# Altium schematic sheet 03 MCU_Disp_Power.SchDoc("03 MCU_Disp_Power")
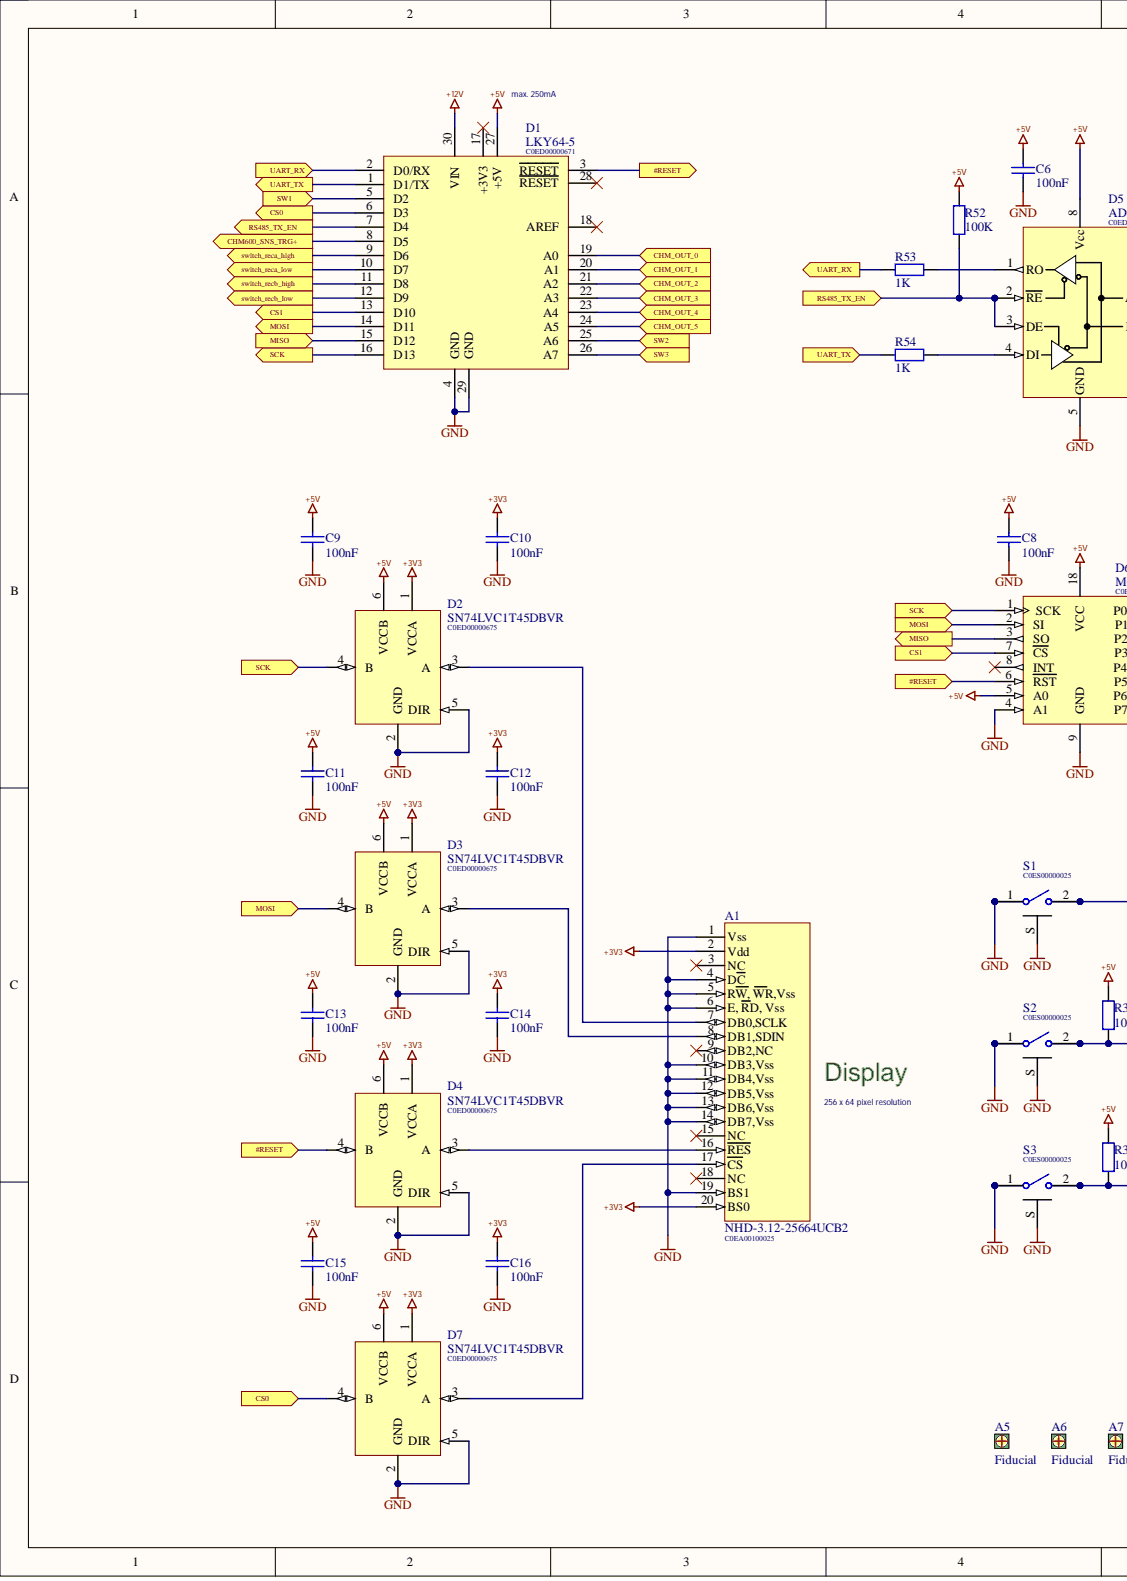
[diagram p0: 03 MCU_Disp_Power.SchDoc, left]
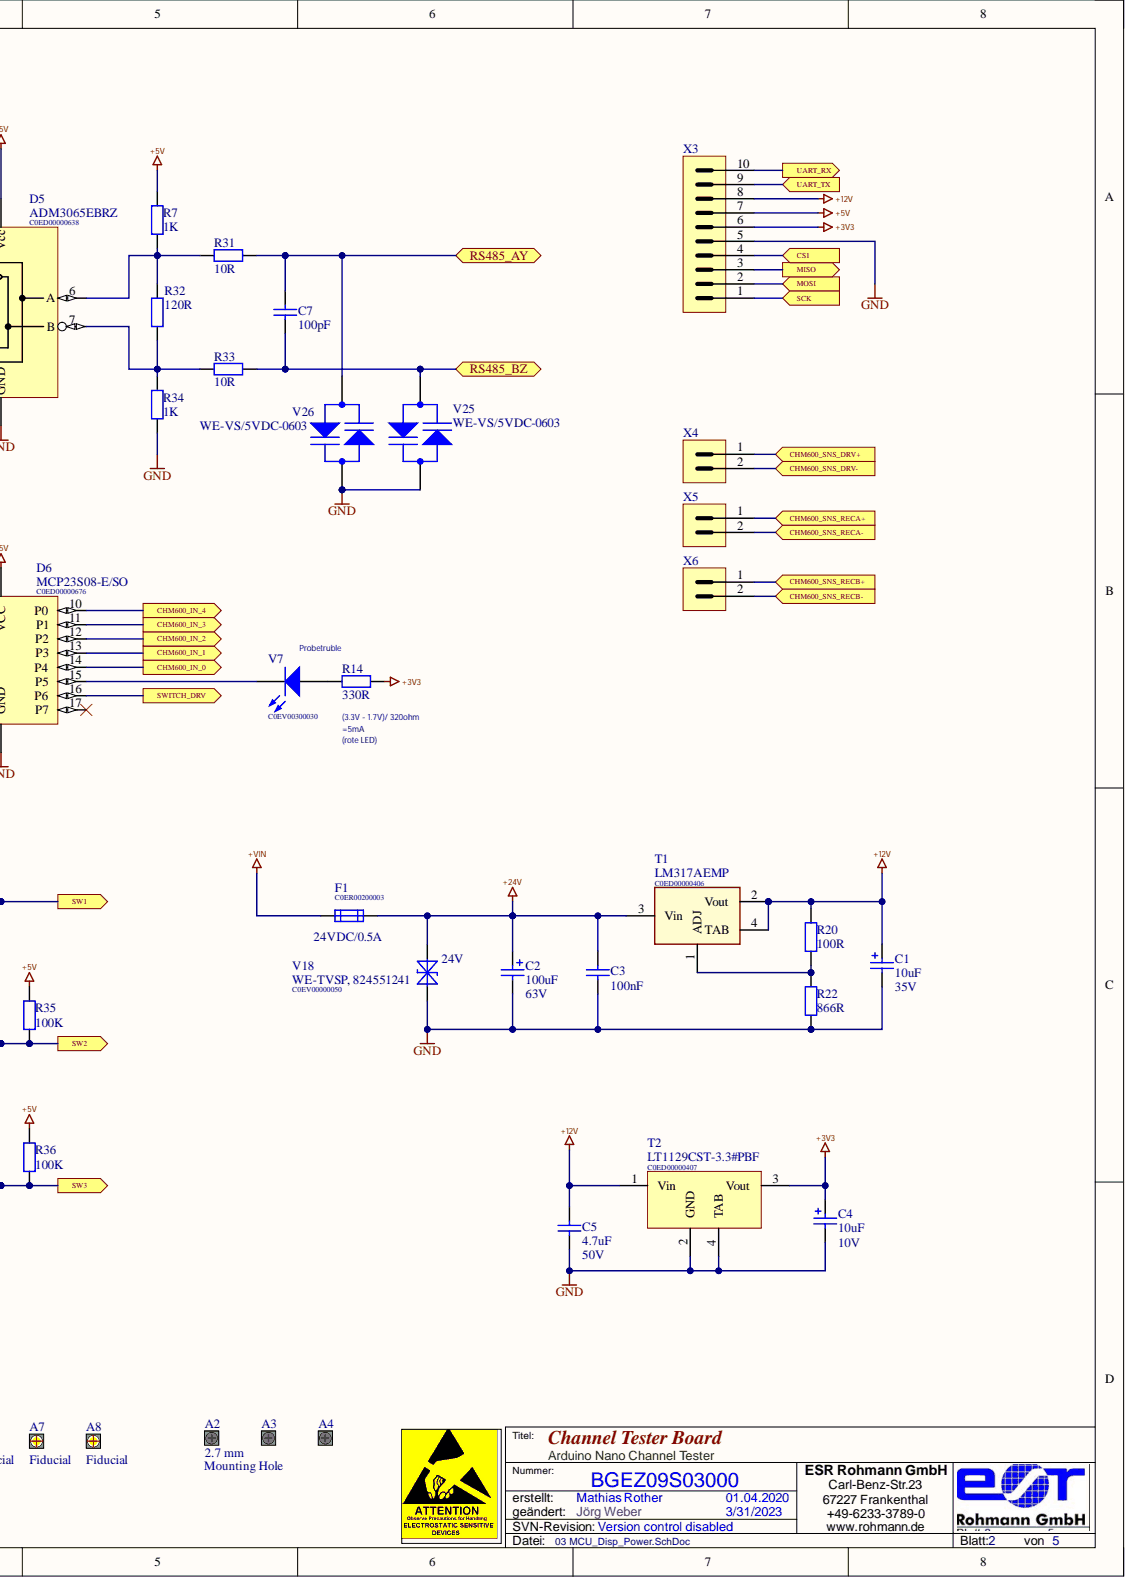
[diagram p1: 03 MCU_Disp_Power.SchDoc, right]

## Parts
A1  value NHD-3.12-25664UCB2  (hidden comment: DISPLAY_OLED)
A2  Mounting Hole  value 2.7 mm
A3  Mounting Hole
A4  Mounting Hole
A5  Fiducial
A6  Fiducial
A7  Fiducial
A8  Fiducial
C1  value 10uF  VOLTAGE: 35V  (hidden comment: CapPol)
C2  value 100uF  VOLTAGE: 63V  (hidden comment: Cap Pol1)
C3  value 100nF  (hidden comment: Cap)
C4  value 10uF  VOLTAGE: 10V  (hidden comment: CapPol)
C5  value 4.7uF  VOLTAGE: 50V  (hidden comment: Cap)
C6  value 100nF  (hidden comment: Cap)
C7  value 100pF  (hidden comment: Cap)
C8  value 100nF  (hidden comment: Cap)
C9  value 100nF  (hidden comment: Cap)
C10  value 100nF  (hidden comment: Cap)
C11  value 100nF  (hidden comment: Cap)
C12  value 100nF  (hidden comment: Cap)
C13  value 100nF  (hidden comment: Cap)
C14  value 100nF  (hidden comment: Cap)
C15  value 100nF  (hidden comment: Cap)
C16  value 100nF  (hidden comment: Cap)
D1  value LKY64-5  SEARCH1: LKY64-5  (hidden comment: Arduino_Nano_V3)
D2  value SN74LVC1T45DBVR  SEARCH1: SN74LVC1T45DBVR  (hidden comment: Single-Bit Dual-Supply Bus Transceiver)
D3  value SN74LVC1T45DBVR  SEARCH1: SN74LVC1T45DBVR  (hidden comment: Single-Bit Dual-Supply Bus Transceiver)
D4  value SN74LVC1T45DBVR  SEARCH1: SN74LVC1T45DBVR  (hidden comment: Single-Bit Dual-Supply Bus Transceiver)
D5  ADM3065  value ADM3065EBRZ  SEARCH1: ADM3065EBRZ
D6  MCP23S08  value MCP23S08-E/SO  SEARCH1: MCP23S08-E/SO
D7  value SN74LVC1T45DBVR  SEARCH1: SN74LVC1T45DBVR  (hidden comment: Single-Bit Dual-Supply Bus Transceiver)
F1  RATING: 24VDC/0.5A  (hidden comment: FUSE)
R7  value 1K  (hidden comment: Res2)
R14  value 330R  (hidden comment: Res2)
R20  value 100R  (hidden comment: Res2)
R22  value 866R  (hidden comment: Res2)
R31  value 10R  (hidden comment: Res2)
R32  value 120R  (hidden comment: Res2)
R33  value 10R  (hidden comment: Res2)
R34  value 1K  (hidden comment: Res2)
R35  value 100K  (hidden comment: Res2)
R36  value 100K  (hidden comment: Res2)
R52  value 100K  (hidden comment: Res2)
R53  value 1K  (hidden comment: Res2)
R54  value 1K  (hidden comment: Res2)
S1  SW_WS-TASV  (value not drawn)
S2  SW_WS-TASV  (value not drawn)
S3  SW_WS-TASV  (value not drawn)
T1  value LM317AEMP  SEARCH1: LM317AEMP  (hidden comment: LM317_SOT23)
T2  LT1129CST  value LT1129CST-3.3#PBF  SEARCH1: LT1129CST-3.3#PBF
V7  LED
V18  value WE-TVSP, 824551241  VOLTAGE: 24V  (hidden comment: TVS-DIODE-UNI)
V25  value WE-VS/5VDC-0603  (hidden comment: VARISTOR_BI-DIR)
V26  value WE-VS/5VDC-0603  (hidden comment: VARISTOR_BI-DIR)
X3  HEADER_1X10  (value not drawn)
X4  HEADER_1X2  (value not drawn)
X5  HEADER_1X2  (value not drawn)
X6  HEADER_1X2  (value not drawn)

## Ports
#RESET, CHM600_IN_0, CHM600_IN_1, CHM600_IN_2, CHM600_IN_3, CHM600_IN_4, CHM600_SNS_DRV+, CHM600_SNS_DRV-, CHM600_SNS_RECA+, CHM600_SNS_RECA-, CHM600_SNS_RECB+, CHM600_SNS_RECB-, CHM600_SNS_TRG+, CHM_OUT_0, CHM_OUT_1, CHM_OUT_2, CHM_OUT_3, CHM_OUT_4, CHM_OUT_5, CS0, CS1, MISO, MOSI, RS485_AY, RS485_BZ, RS485_TX_EN, SCK, SW1, SW2, SW3, SWITCH_DRV, switch_reca_high, switch_reca_low, switch_recb_high, switch_recb_low, UART_RX, UART_TX

## Nets
+3V3: A1.2(Vdd) A1.20(BS0) C4.P C10.2 C12.2 C14.2 C16.2 D2.1(VCCA) D3.1(VCCA) D4.1(VCCA) D7.1(VCCA) R14.2 T2.3(Vout) X3.6
+5V: C6.2 C8.2 C9.2 C11.2 C13.2 C15.2 D1.27(+5V) D2.6(VCCB) D3.6(VCCB) D4.6(VCCB) D5.8(Vcc) D6.5(A0) D6.18(VCC) D7.6(VCCB) R7.2 R35.2 R36.2 R52.2 X3.7
+12V: C1.P C5.2 D1.30(VIN) R20.2 T1.2(Vout) T1.4(TAB) T2.1(Vin) X3.8
+24V: C2.1 C3.2 F1.2 T1.3(Vin) V18.1
+VIN: F1.1
GND: A1.1(Vss) A1.4(DC) A1.5(RW,_WR,Vss) A1.6(E,_RD,_Vss) A1.10(DB3,Vss) A1.11(DB4,Vss) A1.12(DB5,Vss) A1.13(DB6,Vss) A1.14(DB7,Vss) A1.19(BS1) C1.N C2.2 C3.1 C4.N C5.1 C6.1 C8.1 C9.1 C10.1 C11.1 C12.1 C13.1 C14.1 C15.1 C16.1 D1.4(GND) D1.29(GND) D2.2(GND) D2.5(DIR) D3.2(GND) D3.5(DIR) D4.2(GND) D4.5(DIR) D5.5(GND) D6.4(A1) D6.9(GND) D7.2(GND) D7.5(DIR) R22.1 R34.1 S1.1 S1.3 S1.S S2.1 S2.3 S2.S S3.1 S3.3 S3.S T2.2(GND) T2.4(TAB) V18.2 V25.1 V26.1 X3.5
#RESET: D1.3(RESET) D4.4(B) D6.6(RST) ; port #RESET
CHM600_IN_0: D6.14(P4) ; port CHM600_IN_0
CHM600_IN_1: D6.13(P3) ; port CHM600_IN_1
CHM600_IN_2: D6.12(P2) ; port CHM600_IN_2
CHM600_IN_3: D6.11(P1) ; port CHM600_IN_3
CHM600_IN_4: D6.10(P0) ; port CHM600_IN_4
CHM600_SNS_DRV+: X4.1 ; port CHM600_SNS_DRV+
CHM600_SNS_DRV-: X4.2 ; port CHM600_SNS_DRV-
CHM600_SNS_RECA+: X5.1 ; port CHM600_SNS_RECA+
CHM600_SNS_RECA-: X5.2 ; port CHM600_SNS_RECA-
CHM600_SNS_RECB+: X6.1 ; port CHM600_SNS_RECB+
CHM600_SNS_RECB-: X6.2 ; port CHM600_SNS_RECB-
CHM600_SNS_TRG+: D1.8(D5) ; port CHM600_SNS_TRG+
CHM_OUT_0: D1.19(A0) ; port CHM_OUT_0
CHM_OUT_1: D1.20(A1) ; port CHM_OUT_1
CHM_OUT_2: D1.21(A2) ; port CHM_OUT_2
CHM_OUT_3: D1.22(A3) ; port CHM_OUT_3
CHM_OUT_4: D1.23(A4) ; port CHM_OUT_4
CHM_OUT_5: D1.24(A5) ; port CHM_OUT_5
CS0: D1.6(D3) D7.4(B) ; port CS0
CS1: D1.13(D10) D6.7(CS) X3.4 ; port CS1
MISO: D1.15(D12) D6.3(SO) X3.3 ; port MISO
MOSI: D1.14(D11) D3.4(B) D6.2(SI) X3.2 ; port MOSI
RS485_AY: C7.2 R31.2 V26.2 ; port RS485_AY
RS485_BZ: C7.1 R33.2 V25.2 ; port RS485_BZ
RS485_TX_EN: D1.7(D4) D5.2(RE) D5.3(DE) R52.1 ; port RS485_TX_EN
SCK: D1.16(D13) D2.4(B) D6.1(SCK) X3.1 ; port SCK
SW1: D1.5(D2) S1.2 S1.4 ; port SW1
SW2: D1.25(A6) R35.1 S2.2 S2.4 ; port SW2
SW3: D1.26(A7) R36.1 S3.2 S3.4 ; port SW3
SWITCH_DRV: D6.16(P6) ; port SWITCH_DRV
switch_reca_high: D1.9(D6) ; port switch_reca_high
switch_reca_low: D1.10(D7) ; port switch_reca_low
switch_recb_high: D1.11(D8) ; port switch_recb_high
switch_recb_low: D1.12(D9) ; port switch_recb_low
UART_RX: D1.2(D0/RX) R53.2 X3.10 ; port UART_RX
UART_TX: D1.1(D1/TX) R54.2 X3.9 ; port UART_TX
NetA1_7: A1.7(DB0,SCLK) D2.3(A)
NetA1_8: A1.8(DB1,SDIN) D3.3(A)
NetA1_16: A1.16(RES) D4.3(A)
NetA1_17: A1.17(CS) D7.3(A)
NetD5_1: D5.1(RO) R53.1
NetD5_4: D5.4(DI) R54.1
NetD5_6: D5.6(A) R7.1 R31.1 R32.2
NetD5_7: D5.7(B) R32.1 R33.1 R34.2
NetD6_15: D6.15(P5) V7.C
NetR14_1: R14.1 V7.A
NetR20_1: R20.1 R22.2 T1.1(ADJ)

Not connected: A1 3(NC) 9(DB2,NC) 15(NC) 18(NC); D1 17(+3V3) 18(AREF) 28(RESET); D6 8(INT) 17(P7)

## Library data of the parts
A1 = C0EA00100025: Newhaven Graphic OLED Display Module,  3.12” diagonal size, 256 x 64 pixel resolution, +2.95V power supply; PART_TYPE: OPTO; Database Table Name: PART_NUMBER_VIEW; REVIEW_STATE: OK; Component Kind: Standard; ComponentLink1Description: Datasheet; ComponentLink1URL: http://apsharepoint/DMS/APplusProd/Forms/Allitems.aspx?RootFolder=%2FDMS%2FAPplusProd%2Fartikel%2FC0EA00100025; ComponentLink2Description: AP-Plus; ComponentLink2URL: http://asseco/APplusProd/masterdata/artikelRec.aspx?ARTIKEL.ARTIKEL=C0EA00100025; ESD-sensitivity: ESD-sensitivity; PART_NUMBER: C0EA00100025; Library Name: esr.SVNDBlib
A2-A4 = Z0000018: Unplated Mounting Hole 2.7mm, Keepout 2.7 mm; PART_TYPE: ELEKTROMECHANISCH; Database Table Name: PART_NUMBER_VIEW; REVIEW_STATE: OK; Component Kind: Standard; ComponentLink1Description: Datasheet; ComponentLink1URL: http://apsharepoint/DMS/APplusProd/Forms/Allitems.aspx?RootFolder=%2FDMS%2FAPplusProd%2Fartikel%2FZ0000018; ComponentLink2Description: AP-Plus; ComponentLink2URL: http://asseco/APplusProd/masterdata/artikelRec.aspx?ARTIKEL.ARTIKEL=Z0000018; ESD-sensitivity: ESD-sensitivity; PART_NUMBER: Z0000018; Library Name: esr.SVNDBlib
  A3 A4: VALUE: 2.7 mm
A5-A8 = Z0000013: Fiducial round; PART_TYPE: ELEKTROMECHANISCH; ACTIVE: Do Not Use; Database Table Name: PART_NUMBER_VIEW; REVIEW_STATE: OK; Component Kind: Standard; ComponentLink1Description: Datasheet; ComponentLink1URL: http://apsharepoint/DMS/APplusProd/Forms/Allitems.aspx?RootFolder=%2FDMS%2FAPplusProd%2Fartikel%2FZ0000013; ComponentLink2Description: AP-Plus; ComponentLink2URL: http://asseco/APplusProd/masterdata/artikelRec.aspx?ARTIKEL.ARTIKEL=Z0000013; ESD-sensitivity: ESD-sensitivity; PART_NUMBER: Z0000013; Library Name: esr.SVNDBlib
C1 = C0EC00000003: Tantal-Elektrolyt-Kondensator TAJ 10uF/35V/10%; PART_TYPE: KONDENSATOREN; Database Table Name: PART_NUMBER_VIEW; PCB_FOOTPRINT: CAPMP7343X280N,CAPMP7343X280L,c_smdd; REVIEW_STATE: OK; SEARCH1: 10uF/35V-SMD-D; SEARCH2: C,SMD,10UF; Component Kind: Standard; ComponentLink1Description: Datasheet; ComponentLink1URL: http://apsharepoint/DMS/APplusProd/Forms/Allitems.aspx?RootFolder=%2FDMS%2FAPplusProd%2Fartikel%2FC0EC00000003; ComponentLink2Description: AP-Plus; ComponentLink2URL: http://asseco/APplusProd/masterdata/artikelRec.aspx?ARTIKEL.ARTIKEL=C0EC00000003; ESD-sensitivity: ESD-sensitivity; PART_NUMBER: C0EC00000003; PRICE: .24; ROHS: ROK; SCHEMATIC_PART: CAP POL; STORAGE: EA0408; Library Name: esr.SVNDBlib
C2 = C0EC00000023: SMD-Aluminium-Elektrolyt-Kondensator, 100uF/50V/20%, Serie ECA, Bauform G; MANUFACTURER: Vishay Measurements Group GmbH; PART_TYPE: KONDENSATOREN; SCHEMATIC_PART: CAP POL; Database Table Name: PART_NUMBER_VIEW; PCB_FOOTPRINT: CAPAE1030X1050N; REVIEW_STATE: OK; SEARCH1: MALSECA00AG310HARK; SEARCH2: C,SMD,100UF; Component Kind: Standard; ComponentLink1Description: Datasheet; ComponentLink1URL: http://apsharepoint/DMS/APplusProd/Forms/Allitems.aspx?RootFolder=%2FDMS%2FAPplusProd%2Fartikel%2FC0EC00000023; ComponentLink2Description: AP-Plus; ComponentLink2URL: http://asseco/APplusProd/masterdata/artikelRec.aspx?ARTIKEL.ARTIKEL=C0EC00000023; ESD-sensitivity: ESD-sensitivity; PART_NUMBER: C0EC00000023; ROHS: ROK; STORAGE: EA0408; Library Name: esr.SVNDBlib
C3 = C002100N: Chip-Kondensator 100nF/50V/5%/X7R; PART_TYPE: KONDENSATOREN; SCHEMATIC_PART: CAP NP; Database Table Name: PART_NUMBER_VIEW; PCB_FOOTPRINT: CAPC2012X145N,CAPC2012X145L,c_0805; REVIEW_STATE: OK; SEARCH1: 100N-SMD0805; SEARCH2: C,SMD,100N; Component Kind: Standard; ComponentLink1Description: Datasheet; ComponentLink1URL: http://apsharepoint/DMS/APplusProd/Forms/Allitems.aspx?RootFolder=%2FDMS%2FAPplusProd%2Fartikel%2FC002100N; ComponentLink2Description: AP-Plus; ComponentLink2URL: http://asseco/APplusProd/masterdata/artikelRec.aspx?ARTIKEL.ARTIKEL=C002100N; ESD-sensitivity: ESD-sensitivity; PART_NUMBER: C002100N; PRICE: .02; ROHS: ROK; STORAGE: EA0408; Library Name: esr.SVNDBlib
C4 = C0EC00000018: SMD-Tantal-Elektrolyt-Kondensator, 10uF/10V/10%, LowESR, Serie TPS, Bauform A; MANUFACTURER: AVX; PART_TYPE: KONDENSATOREN; Database Table Name: PART_NUMBER_VIEW; PCB_FOOTPRINT: CAPMP3216X180N,CAPMP3216X180L,c_smda; REVIEW_STATE: OK; SEARCH1: TPSA106K010R0900; SEARCH2: C,SMD,10UF; Component Kind: Standard; ComponentLink1Description: Datasheet; ComponentLink1URL: http://apsharepoint/DMS/APplusProd/Forms/Allitems.aspx?RootFolder=%2FDMS%2FAPplusProd%2Fartikel%2FC0EC00000018; ComponentLink2Description: AP-Plus; ComponentLink2URL: http://asseco/APplusProd/masterdata/artikelRec.aspx?ARTIKEL.ARTIKEL=C0EC00000018; ESD-sensitivity: ESD-sensitivity; PART_NUMBER: C0EC00000018; PRICE: 1.54; ROHS: ROK; SCHEMATIC_PART: CAP POL; STORAGE: 0; Library Name: esr.SVNDBlib
C5 = C10004U7: Chip-Kondensator 4.7uF/50V/10%/X7R; PART_TYPE: KONDENSATOREN; Database Table Name: PART_NUMBER_VIEW; REVIEW_STATE: OK; SEARCH1: 4,7uF-SMD0805; Component Kind: Standard; ComponentLink1Description: Datasheet; ComponentLink1URL: http://apsharepoint/DMS/APplusProd/Forms/Allitems.aspx?RootFolder=%2FDMS%2FAPplusProd%2Fartikel%2FC10004U7; ComponentLink2Description: AP-Plus; ComponentLink2URL: http://asseco/APplusProd/masterdata/artikelRec.aspx?ARTIKEL.ARTIKEL=C10004U7; ESD-sensitivity: ESD-sensitivity; PART_NUMBER: C10004U7; ROHS: ROK; STORAGE: 0; Library Name: esr.SVNDBlib
C6 C8-C16 = C006100N: Chip-Kondensator 100nF/50V/+80..-20%/Y5V; PART_TYPE: KONDENSATOREN; SCHEMATIC_PART: CAP NP; Database Table Name: PART_NUMBER_VIEW; PCB_FOOTPRINT: CAPC1005X55N,CAPC1005X55L; REVIEW_STATE: OK; SEARCH1: 100N-SMD0402; SEARCH2: C,SMD,100N; VOLTAGE: 25V; Component Kind: Standard; ComponentLink1Description: Datasheet; ComponentLink1URL: http://apsharepoint/DMS/APplusProd/Forms/Allitems.aspx?RootFolder=%2FDMS%2FAPplusProd%2Fartikel%2FC006100N; ComponentLink2Description: AP-Plus; ComponentLink2URL: http://asseco/APplusProd/masterdata/artikelRec.aspx?ARTIKEL.ARTIKEL=C006100N; ESD-sensitivity: ESD-sensitivity; PART_NUMBER: C006100N; ROHS: ROK; STORAGE: 0; Library Name: esr.SVNDBlib
C7 = C006100P: Chip-Kondensator 100pF/50V/5%/C0G; PART_TYPE: KONDENSATOREN; SCHEMATIC_PART: CAP NP; Database Table Name: PART_NUMBER_VIEW; PCB_FOOTPRINT: CAPC1005X55N,CAPC1005X55L; REVIEW_STATE: OK; SEARCH1: 100P-SMD0402; SEARCH2: C,SMD,100P; VOLTAGE: 50V; Component Kind: Standard; ComponentLink1Description: Datasheet; ComponentLink1URL: http://apsharepoint/DMS/APplusProd/Forms/Allitems.aspx?RootFolder=%2FDMS%2FAPplusProd%2Fartikel%2FC006100P; ComponentLink2Description: AP-Plus; ComponentLink2URL: http://asseco/APplusProd/masterdata/artikelRec.aspx?ARTIKEL.ARTIKEL=C006100P; ESD-sensitivity: ESD-sensitivity; PART_NUMBER: C006100P; ROHS: ROK; STORAGE: 0; Library Name: esr.SVNDBlib
D1 = C0ED00000671: Longruner with ArduinoIDE Nano V3.0 ATmega328P 5V 16M Micro Controller Board Module LKY64-5; PART_TYPE: IC; Database Table Name: PART_NUMBER_VIEW; REVIEW_STATE: NEW; Component Kind: Standard; ComponentLink1Description: Datasheet; ComponentLink1URL: http://apsharepoint/DMS/APplusProd/Forms/Allitems.aspx?RootFolder=%2FDMS%2FAPplusProd%2Fartikel%2FC0ED00000671; ComponentLink2Description: AP-Plus; ComponentLink2URL: http://asseco/APplusProd/masterdata/artikelRec.aspx?ARTIKEL.ARTIKEL=C0ED00000671; ESD-sensitivity: ESD-sensitivity; PART_NUMBER: C0ED00000671; ROHS: R; STORAGE: 0; Library Name: esr.SVNDBlib
D2-D4 D7 = C0ED00000675: 1-Kanal Spannungspegelumsetzer Bus Transceiver LVC 3 Zustände, 6-Pin, SOT-23; MANUFACTURER: Texas; PART_TYPE: IC; Database Table Name: PART_NUMBER_VIEW; REVIEW_STATE: NEW; Component Kind: Standard; ComponentLink1Description: Datasheet; ComponentLink1URL: http://apsharepoint/DMS/APplusProd/Forms/Allitems.aspx?RootFolder=%2FDMS%2FAPplusProd%2Fartikel%2FC0ED00000675; ComponentLink2Description: AP-Plus; ComponentLink2URL: http://asseco/APplusProd/masterdata/artikelRec.aspx?ARTIKEL.ARTIKEL=C0ED00000675; ESD-sensitivity: ESD-sensitivity; PART_NUMBER: C0ED00000675; ROHS: R; STORAGE: 130A052U; Library Name: esr.SVNDBlib
D5 = C0ED00000638: 3.0V to 5.5V, ±12 kV IEC ESD Protected, 500 kbps/50 Mbps RS-485 Transceiver ,-40..+125°C, SOICN-8; MANUFACTURER: Analog Devices; PART_TYPE: IC; Database Table Name: PART_NUMBER_VIEW; REVIEW_STATE: OK; Component Kind: Standard; ComponentLink1Description: Datasheet; ComponentLink1URL: http://apsharepoint/DMS/APplusProd/Forms/Allitems.aspx?RootFolder=%2FDMS%2FAPplusProd%2Fartikel%2FC0ED00000638; ComponentLink2Description: AP-Plus; ComponentLink2URL: http://asseco/APplusProd/masterdata/artikelRec.aspx?ARTIKEL.ARTIKEL=C0ED00000638; ESD-sensitivity: ESD-sensitivity; PART_NUMBER: C0ED00000638; ROHS: ROK; STORAGE: 0; Library Name: esr.SVNDBlib
D6 = C0ED00000676: 8-Bit I/O Expander with Serial Interface, SOIC-18,-40°C..+125°C; MANUFACTURER: Microchip; PART_TYPE: IC; Database Table Name: PART_NUMBER_VIEW; REVIEW_STATE: NEW; Component Kind: Standard; ComponentLink1Description: Datasheet; ComponentLink1URL: http://apsharepoint/DMS/APplusProd/Forms/Allitems.aspx?RootFolder=%2FDMS%2FAPplusProd%2Fartikel%2FC0ED00000676; ComponentLink2Description: AP-Plus; ComponentLink2URL: http://asseco/APplusProd/masterdata/artikelRec.aspx?ARTIKEL.ARTIKEL=C0ED00000676; ESD-sensitivity: ESD-sensitivity; PART_NUMBER: C0ED00000676; ROHS: R; STORAGE: 0; Library Name: esr.SVNDBlib
F1 = C0ER00200003: PTC, PolySwitch, 24VDC/0.5A, SMDC; MANUFACTURER: TE Connectivity; PART_TYPE: WIDERSTAENDE; Database Table Name: PART_NUMBER_VIEW; PCB_FOOTPRINT: FUSC4632X62N,C_1812; REVIEW_STATE: OK; SEARCH1: miniSMDC050F-2; SEARCH2: R,PTC; VALUE: miniSMDC050; Component Kind: Standard; ComponentLink1Description: Datasheet; ComponentLink1URL: http://apsharepoint/DMS/APplusProd/Forms/Allitems.aspx?RootFolder=%2FDMS%2FAPplusProd%2Fartikel%2FC0ER00200003; ComponentLink2Description: AP-Plus; ComponentLink2URL: http://asseco/APplusProd/masterdata/artikelRec.aspx?ARTIKEL.ARTIKEL=C0ER00200003; ESD-sensitivity: ESD-sensitivity; PART_NUMBER: C0ER00200003; PRICE: .20; ROHS: ROK; SCHEMATIC_PART: FUSE; STORAGE: 0; Library Name: esr.SVNDBlib
R7 R34 R53 R54 = R-701K00: Chip-Widerstand, 1K/1%/0.063W/TK100/50V; PART_TYPE: WIDERSTAENDE|SMD; Database Table Name: PART_NUMBER_VIEW; REVIEW_STATE: OK; SEARCH1: 1K-SMD0402; SEARCH2: R,SMD,1K; Component Kind: Standard; ComponentLink1Description: Datasheet; ComponentLink1URL: http://apsharepoint/DMS/APplusProd/Forms/Allitems.aspx?RootFolder=%2FDMS%2FAPplusProd%2Fartikel%2FR-701K00; ComponentLink2Description: AP-Plus; ComponentLink2URL: http://asseco/APplusProd/masterdata/artikelRec.aspx?ARTIKEL.ARTIKEL=R-701K00; ESD-sensitivity: ESD-sensitivity; PART_NUMBER: R-701K00; ROHS: ROK; STORAGE: 0; Library Name: esr.SVNDBlib
R14 = R-60330R: Chip-Dickschicht-Widerstand, 330R/1%/0.1W/+-50ppm/50V; PART_TYPE: WIDERSTAENDE; Database Table Name: PART_NUMBER_VIEW; REVIEW_STATE: OK; SEARCH1: 330R-SMD0603; SEARCH2: R,SMD,330R; Component Kind: Standard; ComponentLink1Description: Datasheet; ComponentLink1URL: http://apsharepoint/DMS/APplusProd/Forms/Allitems.aspx?RootFolder=%2FDMS%2FAPplusProd%2Fartikel%2FR-60330R; ComponentLink2Description: AP-Plus; ComponentLink2URL: http://asseco/APplusProd/masterdata/artikelRec.aspx?ARTIKEL.ARTIKEL=R-60330R; ESD-sensitivity: ESD-sensitivity; PART_NUMBER: R-60330R; ROHS: ROK; STORAGE: 0; Library Name: esr.SVNDBlib
R20 = R-60100R: Chip-Dickschicht-Widerstand, 100R/1%/0.1W/+-50ppm/50V; PART_TYPE: WIDERSTAENDE; Database Table Name: PART_NUMBER_VIEW; REVIEW_STATE: OK; SEARCH1: 100R-SMD0603; SEARCH2: R,SMD,100R; Component Kind: Standard; ComponentLink1Description: Datasheet; ComponentLink1URL: http://apsharepoint/DMS/APplusProd/Forms/Allitems.aspx?RootFolder=%2FDMS%2FAPplusProd%2Fartikel%2FR-60100R; ComponentLink2Description: AP-Plus; ComponentLink2URL: http://asseco/APplusProd/masterdata/artikelRec.aspx?ARTIKEL.ARTIKEL=R-60100R; ESD-sensitivity: ESD-sensitivity; PART_NUMBER: R-60100R; PRICE: .04; ROHS: ROK; STORAGE: EA0405; Library Name: esr.SVNDBlib
R22 = R-60866R: Chip-Dickschicht-Widerstand, 866R/1%/0.1W/+-50ppm/50V; PART_TYPE: WIDERSTAENDE; Database Table Name: PART_NUMBER_VIEW; REVIEW_STATE: OK; SEARCH1: 866R-SMD0603; Component Kind: Standard; ComponentLink1Description: Datasheet; ComponentLink1URL: http://apsharepoint/DMS/APplusProd/Forms/Allitems.aspx?RootFolder=%2FDMS%2FAPplusProd%2Fartikel%2FR-60866R; ComponentLink2Description: AP-Plus; ComponentLink2URL: http://asseco/APplusProd/masterdata/artikelRec.aspx?ARTIKEL.ARTIKEL=R-60866R; ESD-sensitivity: ESD-sensitivity; PART_NUMBER: R-60866R; ROHS: ROK; STORAGE: 0; Library Name: esr.SVNDBlib
R31 R33 = R-7010R0: Chip-Widerstand, 10R/5%/0.03W/TK300; PART_TYPE: WIDERSTAENDE; Database Table Name: PART_NUMBER_VIEW; REVIEW_STATE: OK; SEARCH1: 10R-SMD0402; SEARCH2: R,SMD,10R; Component Kind: Standard; ComponentLink1Description: Datasheet; ComponentLink1URL: http://apsharepoint/DMS/APplusProd/Forms/Allitems.aspx?RootFolder=%2FDMS%2FAPplusProd%2Fartikel%2FR-7010R0; ComponentLink2Description: AP-Plus; ComponentLink2URL: http://asseco/APplusProd/masterdata/artikelRec.aspx?ARTIKEL.ARTIKEL=R-7010R0; ESD-sensitivity: ESD-sensitivity; PART_NUMBER: R-7010R0; ROHS: ROK; Library Name: esr.SVNDBlib
R32 = R-70120R: Chip-Widerstand, 120R/1%/0.063W/TK100/50V; PART_TYPE: WIDERSTAENDE|SMD; Database Table Name: PART_NUMBER_VIEW; REVIEW_STATE: OK; SEARCH1: 120R-SMD0402; SEARCH2: R,SMD,120R; Component Kind: Standard; ComponentLink1Description: Datasheet; ComponentLink1URL: http://apsharepoint/DMS/APplusProd/Forms/Allitems.aspx?RootFolder=%2FDMS%2FAPplusProd%2Fartikel%2FR-70120R; ComponentLink2Description: AP-Plus; ComponentLink2URL: http://asseco/APplusProd/masterdata/artikelRec.aspx?ARTIKEL.ARTIKEL=R-70120R; ESD-sensitivity: ESD-sensitivity; PART_NUMBER: R-70120R; ROHS: ROK; STORAGE: 0; Library Name: esr.SVNDBlib
R35 R36 R52 = R-60100K: Chip-Dickschicht-Widerstand, 100K/1%/0.1W/+-50ppm/50V; PART_TYPE: WIDERSTAENDE; Database Table Name: PART_NUMBER_VIEW; REVIEW_STATE: OK; SEARCH1: 100K-SMD0603; SEARCH2: R,SMD,100K; Component Kind: Standard; ComponentLink1Description: Datasheet; ComponentLink1URL: http://apsharepoint/DMS/APplusProd/Forms/Allitems.aspx?RootFolder=%2FDMS%2FAPplusProd%2Fartikel%2FR-60100K; ComponentLink2Description: AP-Plus; ComponentLink2URL: http://asseco/APplusProd/masterdata/artikelRec.aspx?ARTIKEL.ARTIKEL=R-60100K; ESD-sensitivity: ESD-sensitivity; PART_NUMBER: R-60100K; PRICE: .01; ROHS: ROK; STORAGE: EA0406; Library Name: esr.SVNDBlib
S1-S3 = C0ES00000025: Einpoliger Ein/Aus-Schalter (SPST), tastend, 20 mA,5x5x1.5mm; MANUFACTURER: Würth Elektronik GmbH + CO KG; PART_TYPE: ELEKTROMECHANISCH; Database Table Name: PART_NUMBER_VIEW; REVIEW_STATE: NEW; SEARCH1: 431181015816, WS-TSS; VALUE: 431181015816; Component Kind: Standard; ComponentLink1Description: Datasheet; ComponentLink1URL: http://apsharepoint/DMS/APplusProd/Forms/Allitems.aspx?RootFolder=%2FDMS%2FAPplusProd%2Fartikel%2FC0ES00000025; ComponentLink2Description: AP-Plus; ComponentLink2URL: http://asseco/APplusProd/masterdata/artikelRec.aspx?ARTIKEL.ARTIKEL=C0ES00000025; ESD-sensitivity: ESD-sensitivity; PART_NUMBER: C0ES00000025; ROHS: ROK; STORAGE: 0; Library Name: esr.SVNDBlib
T1 = C0ED00000406: 3-Terminal Adjustable Regulator, 1A, SOT-223; MANUFACTURER: National Instruments Germany G; PART_TYPE: IC; Database Table Name: PART_NUMBER_VIEW; REVIEW_STATE: NEW; SEARCH2: IC,SMD,LM317AEMP; Component Kind: Standard; ComponentLink1Description: Datasheet; ComponentLink1URL: http://apsharepoint/DMS/APplusProd/Forms/Allitems.aspx?RootFolder=%2FDMS%2FAPplusProd%2Fartikel%2FC0ED00000406; ComponentLink2Description: AP-Plus; ComponentLink2URL: http://asseco/APplusProd/masterdata/artikelRec.aspx?ARTIKEL.ARTIKEL=C0ED00000406; ESD-sensitivity: ESD-sensitivity; PART_NUMBER: C0ED00000406; ROHS: ROK; STORAGE: 130A052P; Library Name: esr.SVNDBlib
T2 = C0ED00000407: Micropower Low Dropout Regulator, 3.3V, 0..125°C, SOT-223; MANUFACTURER: Linear Technology; PART_TYPE: IC; SCHEMATIC_PART: LT1129_ST; Database Table Name: PART_NUMBER_VIEW; PCB_FOOTPRINT: SOT230P700X180-4N,sot223; REVIEW_STATE: NEW; SEARCH2: IC,SMD,LT1129; Component Kind: Standard; ComponentLink1Description: Datasheet; ComponentLink1URL: http://apsharepoint/DMS/APplusProd/Forms/Allitems.aspx?RootFolder=%2FDMS%2FAPplusProd%2Fartikel%2FC0ED00000407; ComponentLink2Description: AP-Plus; ComponentLink2URL: http://asseco/APplusProd/masterdata/artikelRec.aspx?ARTIKEL.ARTIKEL=C0ED00000407; ESD-sensitivity: ESD-sensitivity; PART_NUMBER: C0ED00000407; PRICE: 2.69; ROHS: ROK; STORAGE: EA0407; Library Name: esr.SVNDBlib
V7 = C0EV00300030: LED, rot, SMD0603, 5V/100mA; MANUFACTURER: Würth Elektronik GmbH + CO KG; PART_TYPE: OPTO; Database Table Name: PART_NUMBER_VIEW; REVIEW_STATE: NEW; SEARCH1: WL-SMCW , 150060RS75000; VALUE: WL-SMCW , 150060RS75; Component Kind: Standard; ComponentLink1Description: Datasheet; ComponentLink1URL: http://apsharepoint/DMS/APplusProd/Forms/Allitems.aspx?RootFolder=%2FDMS%2FAPplusProd%2Fartikel%2FC0EV00300030; ComponentLink2Description: AP-Plus; ComponentLink2URL: http://asseco/APplusProd/masterdata/artikelRec.aspx?ARTIKEL.ARTIKEL=C0EV00300030; ESD-sensitivity: ESD-sensitivity; PART_NUMBER: C0EV00300030; ROHS: ROK; STORAGE: 0; Library Name: esr.SVNDBlib
V18 = C0EV00000050: Power TVS Diode WE-TVS,24VDC, DO-214AB; MANUFACTURER: Würth Elektronik GmbH + CO KG; PART_TYPE: DIODEN; Database Table Name: PART_NUMBER_VIEW; REVIEW_STATE: NEW; Component Kind: Standard; ComponentLink1Description: Datasheet; ComponentLink1URL: http://apsharepoint/DMS/APplusProd/Forms/Allitems.aspx?RootFolder=%2FDMS%2FAPplusProd%2Fartikel%2FC0EV00000050; ComponentLink2Description: AP-Plus; ComponentLink2URL: http://asseco/APplusProd/masterdata/artikelRec.aspx?ARTIKEL.ARTIKEL=C0EV00000050; ESD-sensitivity: ESD-sensitivity; PART_NUMBER: C0EV00000050; ROHS: ROK; SEARCH1: WE-TVSP, 824551241; STORAGE: 0; Library Name: esr.SVNDBlib
V25 V26 = C0EV00100003: Varistor, ESD Ultra Low CAP TVS, 5VDC, 0603, 82306050029; MANUFACTURER: Würth Elektronik GmbH + CO KG; PART_TYPE: WIDERSTAENDE|NICHTLINEAR; SCHEMATIC_PART: VARISTOR BI-DIR; Database Table Name: PART_NUMBER_VIEW; PCB_FOOTPRINT: RESC1608X50AN,RESC1608X50AL; REVIEW_STATE: OK; SEARCH1: WE-VE/5VDC-0603; SEARCH2: VAR; Component Kind: Standard; ComponentLink1Description: Datasheet; ComponentLink1URL: http://apsharepoint/DMS/APplusProd/Forms/Allitems.aspx?RootFolder=%2FDMS%2FAPplusProd%2Fartikel%2FC0EV00100003; ComponentLink2Description: AP-Plus; ComponentLink2URL: http://asseco/APplusProd/masterdata/artikelRec.aspx?ARTIKEL.ARTIKEL=C0EV00100003; ESD-sensitivity: ESD-sensitivity; MANU_PART_NUMBER: 82306050029; PART_NUMBER: C0EV00100003; PRICE: .27; ROHS: ROK; STORAGE: EA0407; Library Name: esr.SVNDBlib
X3 = Z0000047: Pfostenleiste 10pol. abgewinkelt; PART_TYPE: ELEKTROMECHANISCH; ACTIVE: Do Not Use; Database Table Name: PART_NUMBER_VIEW; REVIEW_STATE: OK; Component Kind: Standard; ComponentLink1Description: Datasheet; ComponentLink1URL: http://apsharepoint/DMS/APplusProd/Forms/Allitems.aspx?RootFolder=%2FDMS%2FAPplusProd%2Fartikel%2FZ0000047; ComponentLink2Description: AP-Plus; ComponentLink2URL: http://asseco/APplusProd/masterdata/artikelRec.aspx?ARTIKEL.ARTIKEL=Z0000047; ESD-sensitivity: ESD-sensitivity; PART_NUMBER: Z0000047; Library Name: esr.SVNDBlib
X4-X6 = Z0000049: Pfostenleiste 2pol.; PART_TYPE: ELEKTROMECHANISCH; ACTIVE: Do Not Use; Database Table Name: PART_NUMBER_VIEW; REVIEW_STATE: OK; Component Kind: Standard; ComponentLink1Description: Datasheet; ComponentLink1URL: http://apsharepoint/DMS/APplusProd/Forms/Allitems.aspx?RootFolder=%2FDMS%2FAPplusProd%2Fartikel%2FZ0000049; ComponentLink2Description: AP-Plus; ComponentLink2URL: http://asseco/APplusProd/masterdata/artikelRec.aspx?ARTIKEL.ARTIKEL=Z0000049; ESD-sensitivity: ESD-sensitivity; PART_NUMBER: Z0000049; Library Name: esr.SVNDBlib
